# Altium schematic sheet lv_power_12V_cv.SchDoc(lv_power_12V_cv)
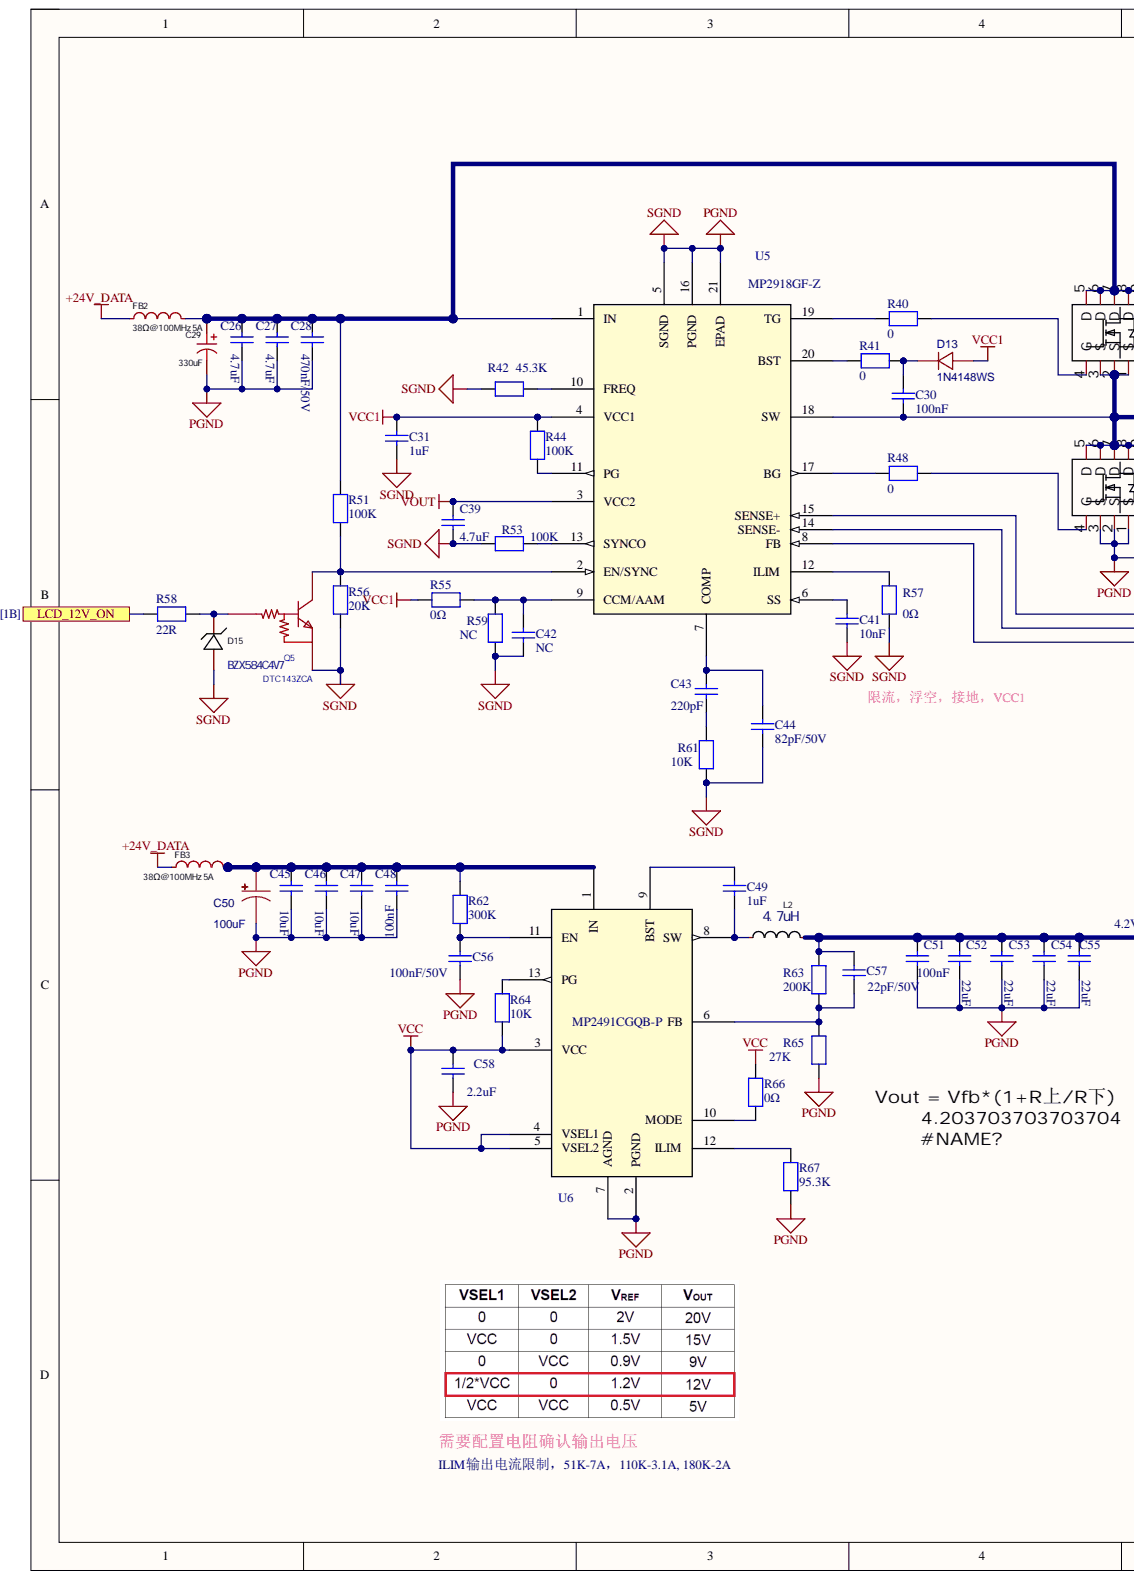
[diagram p0: lv_power_12V_cv.SchDoc, left]
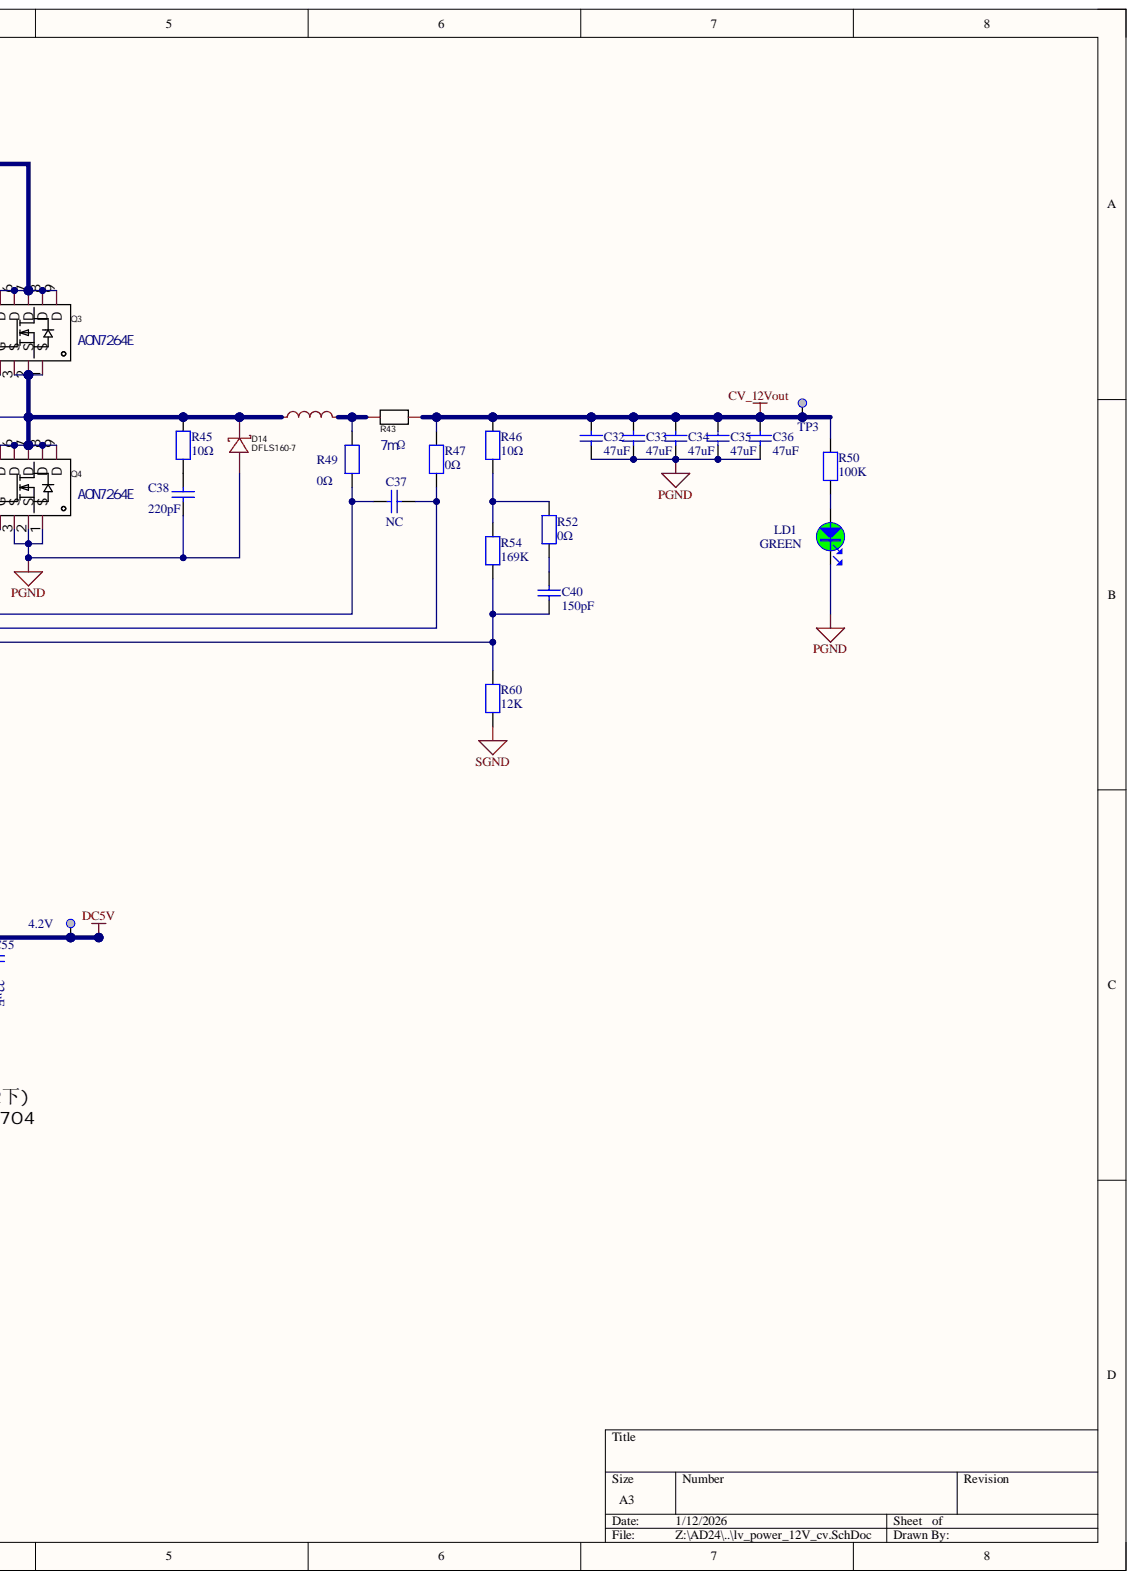
[diagram p1: lv_power_12V_cv.SchDoc, right]

## Parts
C26  4.7uF  [C1206]
C27  4.7uF  [C1206]
C28  470nF/50V  [C0603]
C29  330uF  [CAP-SMD_BD10.0-L10.3-W10.3-LS10.9-FD]
C30  100nF  [C0402]
C31  1uF  [C0402]
C32  47uF  [1206]
C33  47uF  [1206]
C34  47uF  [1206]
C35  47uF  [1206]
C36  47uF  [1206]
C37  NC  [C0402]
C38  220pF  [C0603]
C39  4.7uF  [C0603]
C40  150pF  [C0402]
C41  10nF  [C0402]
C42  NC  [C0402]
C43  220pF  [C0402]
C44  82pF/50V  [C0402]
C45  10uF  [C1206]
C46  10uF  [C1206]
C47  10uF  [C1206]
C48  100nF  [C0402]
C49  1uF  [C0805]
C50  100uF  [CAP-SMD_BD6.3-L6.6-W6.6-LS7.6-FD]
C51  100nF  [C0402]
C52  22uF  [C0805]
C53  22uF  [C0805]
C54  22uF  [C0805]
C55  22uF  [C0805]
C56  100nF/50V  [C0402]
C57  22pF/50V  [C0402]
C58  2.2uF  [C0603]
D13  1N4148WS  [SOD-123_L2.8-W1.8-LS3.7-RD]
D14  DFLS160-7  [POWERDI-123_L2.8-W1.8-LS3.7-RD]
D15  BZX584C4V7  [SOD-523F_L1.2-W0.8-LS1.7-RD]
FB2  PZ3216D380-5R0TF  [L1206]  (value not drawn)
FB3  LCSC Part Name: 38Ω@100MHz 5A  [L1206]  (hidden comment: PZ3216D380-5R0TF)
L1  15uH  [IND-SMD_L11.9-W11.0]  (value not drawn)
L2  4.7uH  [IND-SMD_L7.2-W6.6_GPSR07X0]
LD1  value GREEN  [LED_0603_GREEN]  (hidden comment: LED_green)
Q3  AON7264E  [DFN-8_L3.0-W3.0-P0.65-BL_VBQFXXXX]
Q4  AON7264E  [DFN-8_L3.0-W3.0-P0.65-BL_VBQFXXXX]
Q5  DTC143ZCA  [SOT-416_L1.6-W0.8-P1.00-LS1.6-BR]
R40  0  [R0603]
R41  0  [R0603]
R42  45.3K  [R0402]
R43  7mΩ  [R2512]
R44  100K  [R0402]
R45  10Ω  [R0603]
R46  10Ω  [R0603]
R47  0Ω  [R0402]
R48  0  [R0603]
R49  0Ω  [R0402]
R50  100K  [R0603]
R51  100K  [R0603]
R52  0Ω  [R0603]
R53  100K  [R0402]
R54  169K  [R0603]
R55  0Ω  [R0402]
R56  20K  [R0603]
R57  0Ω  [R0402]
R58  22R  [R0402]
R59  NC  [R0402]
R60  12K  [R0603]
R61  10K  [R0402]
R62  300K  [R0603]
R63  200K  [R0402]
R64  10K  [R0402]
R65  27K  [R0402]
R66  0Ω  [R0402]
R67  95.3K  [R0402]
TP3  Test Point  [TP_SMD_1MM]  (value not drawn)  (no BOM)
TP4  4.2V  [TP_SMD_1MM]  (no BOM)
U5  MP2918GF-Z  [TSSOP-20EP_MP2918_MNP]
U6  MP2491CGQB-P  [QFN-13_2p5MMx3MM_MNP]

## Ports
LCD_12V_ON

## Nets
+24V_DATA: FB2.1 FB3.1
CV_12Vout: C32.2 C33.2 C34.2 C35.2 C36.2 R43.2 R46.2 R47.2 R50.2 TP3.1
DC5V: C51.1 C52.2 C53.2 C54.2 C55.2 C57.2 L2.2 R63.1 TP4.1
PGND: C26.1 C27.1 C28.1 C29.2 C31.2 C32.1 C33.1 C34.1 C35.1 C36.1 C38.2 C39.1 C41.2 C42.2 C44.2 C45.1 C46.1 C47.1 C48.2 C50.2 C51.2 C52.1 C53.1 C54.1 C55.1 C56.1 C58.1 D14.2 D15.2 LD1.2 Q4.1(S) Q4.2(S) Q4.3(S) Q5.2 R42.1 R53.2 R56.2 R57.2 R59.2 R60.1 R61.2 R65.2 R67.2 U5.5(SGND) U5.16(PGND) U5.21(EPAD) U6.2(PGND) U6.7(AGND)
VCC: C58.2 R64.1 R66.2 U6.3(VCC) U6.4(VSEL1) U6.5(VSEL2)
VCC1: C31.1 D13.2 R44.2 R55.1 U5.4(VCC1)
VOUT: C39.2 U5.3(VCC2)
LCD_12V_ON: R58.1 ; port LCD_12V_ON
NetC26_2: C26.2 C27.2 C28.2 C29.1 FB2.2 Q3.5(D) Q3.6(D) Q3.7(D) Q3.8(D) Q3.9(D) R51.1 U5.1(IN)
NetC30_1: C30.1 D13.1 R41.2
NetC30_2: C30.2 D14.1 L1.1 Q3.1(S) Q3.2(S) Q3.3(S) Q4.5(D) Q4.6(D) Q4.7(D) Q4.8(D) Q4.9(D) R45.2 U5.18(SW)
NetC37_1: C37.1 R49.1 U5.15(SENSE+)
NetC37_2: C37.2 R47.1 U5.14(SENSE-)
NetC38_1: C38.1 R45.1
NetC40_1: C40.1 R54.1 R60.2 U5.8(FB)
NetC40_2: C40.2 R52.1
NetC41_1: C41.1 U5.6(SS)
NetC42_1: C42.1 R55.2 R59.1 U5.9(CCM/AAM)
NetC43_1: C43.1 C44.1 U5.7(COMP)
NetC43_2: C43.2 R61.1
NetC45_2: C45.2 C46.2 C47.2 C48.1 C50.1 FB3.2 R62.2 U6.1(IN)
NetC49_1: C49.1 U6.9(BST)
NetC49_2: C49.2 L2.1 U6.8(SW)
NetC56_2: C56.2 R62.1 U6.11(EN)
NetC57_1: C57.1 R63.2 R65.1 U6.6(FB)
NetD15_1: D15.1 Q5.1 R58.2
NetL1_2: L1.2 R43.1 R49.2
NetLD1_1: LD1.1 R50.1
NetQ3_4: Q3.4(G) R40.2
NetQ4_4: Q4.4(G) R48.2
NetQ5_3: Q5.3 R51.2 R56.1 U5.2(EN/SYNC)
NetR40_1: R40.1 U5.19(TG)
NetR41_1: R41.1 U5.20(BST)
NetR42_2: R42.2 U5.10(FREQ)
NetR44_1: R44.1 U5.11(PG)
NetR46_1: R46.1 R52.2 R54.2
NetR48_1: R48.1 U5.17(BG)
NetR53_1: R53.1 U5.13(SYNCO)
NetR57_1: R57.1 U5.12(ILIM)
NetR64_2: R64.2 U6.13(PG)
NetR66_1: R66.1 U6.10(MODE)
NetR67_1: R67.1 U6.12(ILIM)

## Library data of the parts
C26 C27 = Capacitor_2: 50V, X7R, 10%; Internal_Part_Number: MCD-00014; Manufacturer: muRata; Manufacturer_Part_Number: GRM31CR71H475KA12L; Component Kind: Standard; ComponentLink1Description: Datasheet; ComponentLink1URL: http://tunmcdsvn.tun.st.com/Component_Datasheet_Library/Trunk/Capacitors/Yageo_Multilayer_capacitor_X5R_series_100pF_to_100uF; Library Name: HiPin_Library_AD.IntLib; Value: 4.7uF
C28 = Capacitor_2: 50V, X7R, 10%; Manufacturer: YAGEO; Manufacturer_Part_Number: CC0603KRX7R9BB474; Component Kind: Standard; ComponentLink1Description: Datasheet; ComponentLink1URL: http://tunmcdsvn.tun.st.com/Component_Datasheet_Library/Trunk/Capacitors/Yageo_Multilayer_capacitor_X5R_series_100pF_to_100uF; Library Name: HiPin_Library_AD.IntLib
C29 = HV1V337M1010PZ: 330uF, 35V, 20%; Manufacturer: HONOR(荣誉); Add into BOM: yes; L: 10mm; Component Kind: Standard; Convert to PCB: yes; Datasheet: https://atta.szlcsc.com/upload/public/pdf/source/20240802/61683F5000C7CFED5606ABBE3CFBE0F5.pdf; Device: HV1V337M1010PZ; JLCPCB Part Class: Extended Part; LCSC Part Name: 330uF ±20% 35V; Library Name: HiPin_Library_AD.IntLib; Manufacturer Part: HV1V337M1010PZ; Operating Temperature: -55℃~+105℃; Rated Voltage: 35V; Supplier: LCSC; Supplier Footprint: SMD,D10xL10mm; Supplier Part: C5246558; Symbol: HV1V337M1010PZ; Tolerance: ±20%; Value: 330uF; φD: 10mm
C30 = Capacitor: 50V, 10%, X7R; Manufacturer_Part_Number: GRM155R61E105KA12D; Component Kind: Standard; ComponentLink1Description: Datasheet; ComponentLink1URL: http://tunmcdsvn.tun.st.com/Component_Datasheet_Library/Trunk/Capacitors/Yageo_Capacitor_NP0_16V-to-50V; Library Name: HiPin_Library_AD.IntLib; Package: C0402; Value: 100nF
C31 = Capacitor: 25V, 10%, X5R; Manufacturer_Part_Number: GRM155R61E105KA12D; Component Kind: Standard; ComponentLink1Description: Datasheet; ComponentLink1URL: http://tunmcdsvn.tun.st.com/Component_Datasheet_Library/Trunk/Capacitors/Yageo_Capacitor_NP0_16V-to-50V; Library Name: HiPin_Library_AD.IntLib; Package: C0402; Value: 1uF
C32-C36 = Capacitor_3: 25V, X5R, 20%; Internal_Part_Number: MCD-00008; Manufacturer: muRata; Manufacturer_Part_Number: GRM31CR61E476ME44L; Component Kind: Standard; Library Name: HiPin_Library_AD.IntLib; Value: 47uF
C37 C38 C43 = Capacitor: 50V, 5%,C0G; Manufacturer_Part_Number: GCM1885C1H221JA16D; Component Kind: Standard; ComponentLink1Description: Datasheet; ComponentLink1URL: http://tunmcdsvn.tun.st.com/Component_Datasheet_Library/Trunk/Capacitors/Yageo_Capacitor_NP0_16V-to-50V; Library Name: HiPin_Library_AD.IntLib
  C37 C43: Package: C0402
C39 = Capacitor_1: 16V, X7S, 10%; Internal_Part_Number: MCD-00009; Manufacturer: muRata; Manufacturer_Part_Number: GRM188C71C475KE21D; Component Kind: Standard; ComponentLink1Description: Yageo_Capacitor_X5R-series.pdf; ComponentLink1URL: http://tunmcdsvn.tun.st.com/Component_Datasheet_Library/Trunk/Capacitors/Yageo_Multilayer_capacitor_X5R_series_100pF_to_100uF; Library Name: HiPin_Library_AD.IntLib; Package: C0603; Value: 4.7uF
C40 = Capacitor: 50V, 5%,C0G; Manufacturer_Part_Number: GRM1555C1H151JA01D; Component Kind: Standard; ComponentLink1Description: Datasheet; ComponentLink1URL: http://tunmcdsvn.tun.st.com/Component_Datasheet_Library/Trunk/Capacitors/Yageo_Capacitor_NP0_16V-to-50V; Library Name: HiPin_Library_AD.IntLib; Package: C0402
C41 = Capacitor: 25V, 5%, C0G; Manufacturer_Part_Number: GRM1555C1E103JE01D; Component Kind: Standard; ComponentLink1Description: Datasheet; ComponentLink1URL: http://tunmcdsvn.tun.st.com/Component_Datasheet_Library/Trunk/Capacitors/Yageo_Capacitor_NP0_16V-to-50V; Library Name: HiPin_Library_AD.IntLib; Package: C0402
C42 = Capacitor: 25V, 10%, X5R; Manufacturer_Part_Number: GRM155R61E105KA12D; Value: 1uF; Component Kind: Standard; ComponentLink1Description: Datasheet; ComponentLink1URL: http://tunmcdsvn.tun.st.com/Component_Datasheet_Library/Trunk/Capacitors/Yageo_Capacitor_NP0_16V-to-50V; Library Name: HiPin_Library_AD.IntLib; Package: C0402
C44 = Capacitor: 50V, 1%, NP0; Manufacturer: YAGEO; Manufacturer_Part_Number: CC0402FRNPO9BN820; Component Kind: Standard; Library Name: HiPin_Library_AD.IntLib; Package: C0402
C45-C47 = Capacitor_2: 35V, X5R, 10%; Internal_Part_Number: MCD-00014; Manufacturer: muRata; Manufacturer_Part_Number: GRM31CR61H106KA12L; Component Kind: Standard; ComponentLink1Description: Datasheet; ComponentLink1URL: http://tunmcdsvn.tun.st.com/Component_Datasheet_Library/Trunk/Capacitors/Yageo_Multilayer_capacitor_X5R_series_100pF_to_100uF; Library Name: HiPin_Library_AD.IntLib; Value: 10uF
C48 C51 = Capacitor: 50V, 10%, X7R; Internal_Part_Number: MCD-00003; Manufacturer: muRata; Manufacturer_Part_Number: GRM155R71H104KE14D; Component Kind: Standard; ComponentLink1Description: Datasheet; ComponentLink1URL: http://tunmcdsvn.tun.st.com/Component_Datasheet_Library/Trunk/Capacitors/Yageo_Capacitor_NP0_16V-to-50V; Library Name: HiPin_Library_AD.IntLib; Package: C0402; Value: 100nF
C49 = Capacitor: 50V, 10%, X7R; Internal_Part_Number: MCD-00003; Manufacturer_Part_Number: GRM21BR71H105KA12L; Component Kind: Standard; ComponentLink1Description: Datasheet; ComponentLink1URL: http://tunmcdsvn.tun.st.com/Component_Datasheet_Library/Trunk/Capacitors/Yageo_Capacitor_NP0_16V-to-50V; Library Name: HiPin_Library_AD.IntLib; Package: C0805; Value: 1uF
C50 = 100uF: 50V, 20%, 229mA@120Hz; Manufacturer: Nichicon; Manufacturer_Part_Number: RVT1H101M0607; Component Kind: Standard; Library Name: HiPin_Library_AD.IntLib
C52-C55 = Capacitor_2: 25V, X5R, 10%; Internal_Part_Number: MCD-00014; Manufacturer: muRata; Manufacturer_Part_Number: GRM21BR61E226ME44L; Component Kind: Standard; ComponentLink1Description: Datasheet; ComponentLink1URL: http://tunmcdsvn.tun.st.com/Component_Datasheet_Library/Trunk/Capacitors/Yageo_Multilayer_capacitor_X5R_series_100pF_to_100uF; Library Name: HiPin_Library_AD.IntLib; Value: 22uF
C56 = Capacitor: 50V, 10%, X7R; Manufacturer: muRata; Manufacturer_Part_Number: GRM155R71H104KE14D; Component Kind: Standard; ComponentLink1Description: Datasheet; ComponentLink1URL: http://tunmcdsvn.tun.st.com/Component_Datasheet_Library/Trunk/Capacitors/Yageo_Capacitor_NP0_16V-to-50V; Library Name: HiPin_Library_AD.IntLib; Package: C0402
C57 = Capacitor: 50V, 10%, NP0; Manufacturer: muRata; Manufacturer_Part_Number: CC0402FRNPO9BN220; Component Kind: Standard; Library Name: HiPin_Library_AD.IntLib
C58 = Capacitor_1: 25V, X5R, 10%; Internal_Part_Number: MCD-00009; Manufacturer: muRata; Manufacturer_Part_Number: GRM155R61E225KE11D; Component Kind: Standard; ComponentLink1Description: Yageo_Capacitor_X5R-series.pdf; ComponentLink1URL: http://tunmcdsvn.tun.st.com/Component_Datasheet_Library/Trunk/Capacitors/Yageo_Multilayer_capacitor_X5R_series_100pF_to_100uF; Library Name: HiPin_Library_AD.IntLib; Package: C0603; Value: 2.2uF
D13 = 1N4148W-C84367: BOM_Manufacturer: MDD; BOM_JLCPCB Part Class: Extended Part; BOM_Manufacturer Part: 1N4148W; BOM_Supplier: LCSC; BOM_Supplier Part: C84367; Component Kind: Standard; Library Name: HiPin_Library_AD.IntLib; spicePre: D; spiceSymbolName: 1N4148W-C84367
D14 = DFLS160-7: Manufacturer: DIODES(美台); Add into BOM: yes; Forward Voltage (Vf@If): 500mV@1A; Rectified Current: 1A; Reverse Voltage (Vr): 60V; Component Kind: Standard; Convert to PCB: yes; Datasheet: https://atta.szlcsc.com/upload/public/pdf/source/20181114/C177023_80F0A2460033305602CFDDCB3101FB4E.pdf; Device: DFLS160-7; JLCPCB Part Class: Extended Part; LCSC Part Name: 电压:60V 电流:1A; Library Name: HiPin_Library_AD.IntLib; Manufacturer Part: DFLS160-7; PCB3D: POWERDI-123_L2.8-W1.8-H1.0-LS3.7; Supplier: LCSC; Supplier Footprint: Power-DI-123; Supplier Part: C177023; Symbol: DFLS160-7
D15 = LM5Z3V6T1G: 4.8~5.4V, 200mW; Power Dissipation: 500mW; Component Kind: Standard; Library Name: HiPin_Library_AD.IntLib; Manufacturer Part: BZX584C4V7; PCB3D: SOD-123_L2.7-W1.6-H1.4-LS3.7; Supplier Footprint: SOD-123; Tolerance: -
FB2 FB3 = BLM31PG601SN1L: Manufacturer: Sunlord(顺络); Add into BOM: yes; Circuits: 1; Current Rating: 1.5A; DC Resistance: 80mΩ; Impedance @ Frequency: 600Ω@100MHz; Tolerance: ±25%; Component Kind: Standard; Convert to PCB: yes; Datasheet: https://atta.szlcsc.com/upload/public/pdf/source/20180326/C88997_FE7208E239BA92CF7577D85CF2703D30.pdf; Device: BLM31PG601SN1L; JLCPCB Part Class: Extended Part; Library Name: HiPin_Library_AD.IntLib; Manufacturer Part: PZ3216D380-5R0TF; Supplier: LCSC; Supplier Footprint: 1206; Supplier Part: C16902; Symbol: BLM31PG601SN1L
  FB2: LCSC Part Name: 38Ω@100MHz 5A
L1 = FEXL1010A-150M: 电感值: 15uH  精度: ±20%  额定电流: 13.8A  饱和电流(Isat): 15.5A; Manufacturer: cjiang(长江微电); Add into BOM: yes; DC Resistance (DCR): 19.3mΩ; Type: 一体成型电感; Component Kind: Standard; Convert to PCB: yes; Datasheet: https://atta.szlcsc.com/upload/public/pdf/source/20240409/B9FA7ECCC3220F3F7C7BE8F7B1FCE238.pdf; Device: FEXL1010A-150M; JLCPCB Part Class: Extended Part; LCSC Part Name: 15uH ±20% 15.5A; Library Name: HiPin_Library_AD.IntLib; Manufacturer Part: FEXL1010A-150M; PCB3D: IND-SMD_L11.9-W11.0; Rated Current: 13.8A; Ratings: AEC-Q200; Saturation Current (Isat): 15.5A; Supplier: LCSC; Supplier Footprint: SMD,11.9x11mm; Supplier Part: C5378579; Symbol: FEXL1010A-150M; Tolerance: ±20%; Value: 15uH
L2 = CYA0630-10UH: 22.9mΩ，20%, 8A; Manufacturer: PROD; Manufacturer Part: PSPMAA0605H-4R7M-ANP; DC Resistance (DCR): 29mΩ; Tolerance: ±20%; Component Kind: Standard; Library Name: HiPin_Library_AD.IntLib; PCB3D: IND-SMD_L7.2-W6.6-H3.0_GPSR07X0; Supplier Footprint: SMD,6.6x7.2mm; Value: 4.7uH
LD1 = LED_green: LED, Green, 0603; Internal_Part_Number: MCD-00050; Manufacturer: EVERLIGHT; Manufacturer_Part_Number: 19-213SYGC/S530-E2/TR8; Part_Type: Display; ECCN_EU: NEC; ECCN_US: EAR99; Provided_By: SUBCO; Component Kind: Standard; ComponentLink1Description: Datasheet; ComponentLink1URL: http://tunmcdsvn.tun.st.com/Component_Datasheet_Library/Trunk/Display/Everlight_SMD-LED-GREEN_19-213SYGC-S530-XX-TR8-v3.doc; Library Name: mb1367.IntLib; Package: LED 0603; Status: Released
Q3 Q4 = HYG045N03LA1C1: NMOS; Manufacturer: HUAYI(����΢); Add into BOM: yes; Continuous Drain Current (Id): 50A; Drain Source On Resistance (RDS(on)@Vgs,Id): 3.9m��@10V,20A; Drain Source Voltage (Vdss): 30V; Gate Threshold Voltage (Vgs(th)@Id): 1.6V@250uA; Input Capacitance (Ciss@Vds): 2.106nF@25V; Power Dissipation (Pd): 23W; Reverse Transfer Capacitance (Crss@Vds): 228pF@25V; Total Gate Charge (Qg@Vgs): 46.2nC@10V; Type: N����; Component Kind: Standard; Convert to PCB: yes; Datasheet: https://atta.szlcsc.com/upload/public/pdf/source/20211028/5F8459D5B1D3B8F85ED46FBAED5B3F3F.pdf; Device: HYG045N03LA1C1; JLCPCB Part Class: Extended Part; LCSC Part Name: N���� ��ѹ:30V ����:50A; Library Name: HiPin_Library_AD.IntLib; Manufacturer Part: HYG045N03LA1C1; Operating Temperature: -55��~+175��@(Tj); Supplier: LCSC; Supplier Footprint: DFN-8(3x3); Supplier Part: C2911691; Symbol: HYG045N03LA1C1
Q5 = DTC043ZEBTL: NPN 三极管; Manufacturer: ROHM(罗姆); Add into BOM: yes; Collector Current (Ic): 100mA; Collector-Emitter Breakdown Voltage (Vceo): 50V; DC Current Gain (hFE@Ic,Vce): 80@5mA,10V; Input Resistor: 4.7kΩ; Input Voltage (VI(on)@Ic,Vce): 1.1V@5mA,0.3V; Output Voltage (VO(on)@Io/Ii): 150mV@5mA,0.5mA; Power Dissipation (Pd): 150mW; Resistor Ratio: 10; Component Kind: Standard; Convert to PCB: yes; Datasheet: https://atta.szlcsc.com/upload/public/pdf/source/20230825/AFF9D3CF4DD067520C5D7C04408FCBF2.pdf; Device: DTC043ZEBTL; JLCPCB Part Class: Extended Part; LCSC Part Name: 耐压:50V 电流:100mA; Library Name: HiPin_Library_AD.IntLib; Manufacturer Part: DTC043ZEBTL; Operating Temperature: -55℃~+150℃; PCB3D: SOT-416-3P_L1.6-W0.8-H0.9-LS1.6-P1.00; Supplier: LCSC; Supplier Footprint: SOT-416FL; Supplier Part: C96459; Symbol: DTC043ZEBTL; Transistor Type: -
R40-R42 R44-R60 R62 R63 R66 R67 = RES: 1%; Value: 10K; Component Kind: Standard; Library Name: HiPin_Library_AD.IntLib
R43 = PA2512FKE070R005E: R2512-2W-7mR-1%-15ppm; Manufacturer: Milliohm(毫欧); Component Kind: Standard; Library Name: HiPin_Library_AD.IntLib; Manufacturer Part: R2512-2W-7mR-1%-15ppm; PCB3D: RES-SMD_L6.4-W3.2_C500733
R61 R64 R65 = RES: 1%; Component Kind: Standard; Library Name: HiPin_Library_AD.IntLib
  R61 R64: Value: 10K
TP3 TP4 = MCD-00074: Test Point 1mm; Part_Type: Mechanical; Database Table Name: MCD_PARTS$; ComponentLink1Description: Datasheet; ComponentLink1URL: http://na; ECCN_EU: n/a; ECCN_US: n/a; Internal_Part_Number: MCD-00074; Library Name: MCD_PARTS_database.DbLib; Manufacturer: n/a; Manufacturer_Part_Number: n/a; Provided_By: n/a; Status: Released
U5 = MP2918GF-Z: No Description Available; Mfr_Name: Monolithic Power Systems; Component Kind: Standard; Library Name: HiPin_Library_AD.IntLib; RefDes: RefDes; Type: Type
U6 = MP2491CGQB-P: No Description Available; Mfr_Name: Monolithic Power Systems; Component Kind: Standard; Library Name: HiPin_Library_AD.IntLib; RefDes: RefDes; Type: Type
